# Altium schematic sheet Signal Connections.SchDoc("Signal Connections")
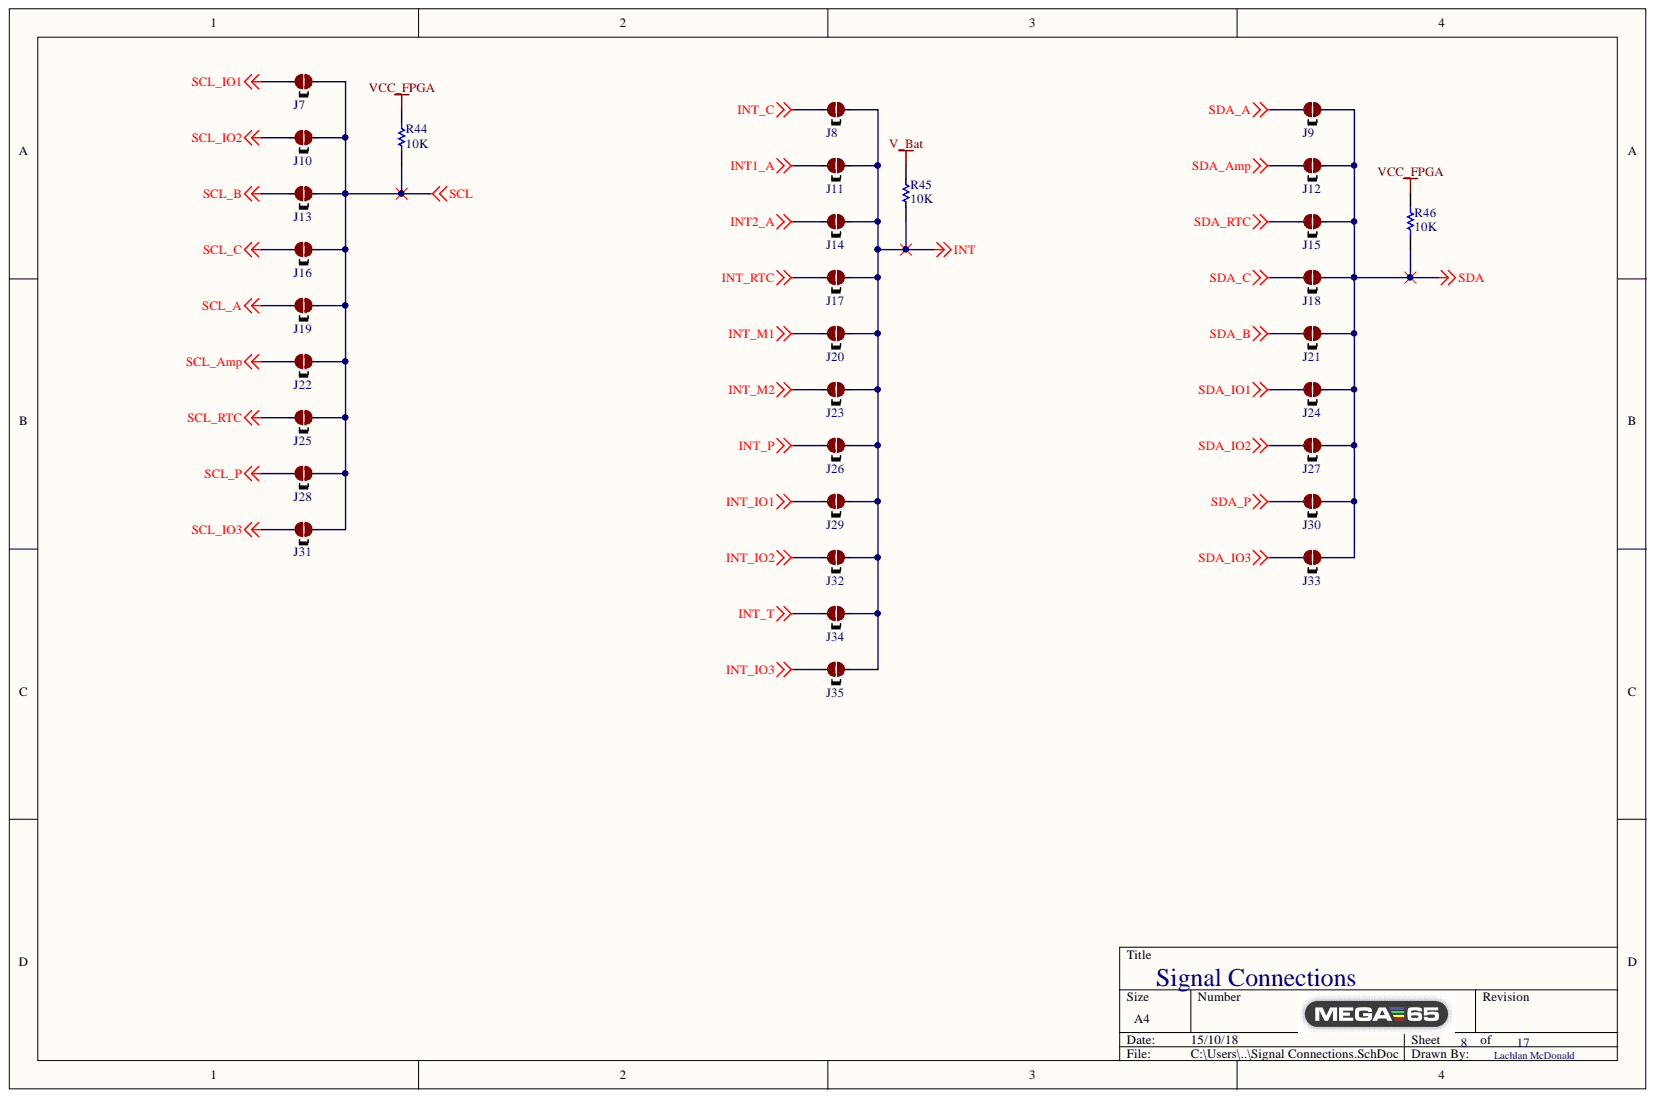
[diagram p0: Signal Connections.SchDoc]

## Parts
J7  2 way net solder junction - Normally Connected  [2waySolder_NC]  (value not drawn)  (no BOM)
J8  2 way net solder junction - Normally Connected  [2waySolder_NC]  (value not drawn)  (no BOM)
J9  2 way net solder junction - Normally Connected  [2waySolder_NC]  (value not drawn)  (no BOM)
J10  2 way net solder junction - Normally Connected  [2waySolder_NC]  (value not drawn)  (no BOM)
J11  2 way net solder junction - Normally Connected  [2waySolder_NC]  (value not drawn)  (no BOM)
J12  2 way net solder junction - Normally Connected  [2waySolder_NC]  (value not drawn)  (no BOM)
J13  2 way net solder junction - Normally Connected  [2waySolder_NC]  (value not drawn)
J14  2 way net solder junction - Normally Connected  [2waySolder_NC]  (value not drawn)  (no BOM)
J15  2 way net solder junction - Normally Connected  [2waySolder_NC]  (value not drawn)  (no BOM)
J16  2 way net solder junction - Normally Connected  [2waySolder_NC]  (value not drawn)
J17  2 way net solder junction - Normally Connected  [2waySolder_NC]  (value not drawn)  (no BOM)
J18  2 way net solder junction - Normally Connected  [2waySolder_NC]  (value not drawn)  (no BOM)
J19  2 way net solder junction - Normally Connected  [2waySolder_NC]  (value not drawn)
J20  2 way net solder junction - Normally Connected  [2waySolder_NC]  (value not drawn)  (no BOM)
J21  2 way net solder junction - Normally Connected  [2waySolder_NC]  (value not drawn)  (no BOM)
J22  2 way net solder junction - Normally Connected  [2waySolder_NC]  (value not drawn)
J23  2 way net solder junction - Normally Connected  [2waySolder_NC]  (value not drawn)  (no BOM)
J24  2 way net solder junction - Normally Connected  [2waySolder_NC]  (value not drawn)  (no BOM)
J25  2 way net solder junction - Normally Connected  [2waySolder_NC]  (value not drawn)
J26  2 way net solder junction - Normally Connected  [2waySolder_NC]  (value not drawn)  (no BOM)
J27  2 way net solder junction - Normally Connected  [2waySolder_NC]  (value not drawn)  (no BOM)
J28  2 way net solder junction - Normally Connected  [2waySolder_NC]  (value not drawn)
J29  2 way net solder junction - Normally Connected  [2waySolder_NC]  (value not drawn)  (no BOM)
J30  2 way net solder junction - Normally Connected  [2waySolder_NC]  (value not drawn)  (no BOM)
J31  2 way net solder junction - Normally Connected  [2waySolder_NC]  (value not drawn)
J32  2 way net solder junction - Normally Connected  [2waySolder_NC]  (value not drawn)  (no BOM)
J33  2 way net solder junction - Normally Connected  [2waySolder_NC]  (value not drawn)  (no BOM)
J34  2 way net solder junction - Normally Connected  [2waySolder_NC]  (value not drawn)  (no BOM)
J35  2 way net solder junction - Normally Connected  [2waySolder_NC]  (value not drawn)  (no BOM)
R44  10K  [RESC0805(2012)_M]
R45  10K  [RESC0805(2012)_M]
R46  10K  [RESC0805(2012)_M]

## Nets
INT: J8.2 J11.2 J14.2 J17.2 J20.2 J23.2 J26.2 J29.2 J32.2 J34.2 J35.2 R45.1
INT1_A: J11.1
INT2_A: J14.1
INT_C: J8.1
INT_IO1: J29.1
INT_IO2: J32.1
INT_IO3: J35.1
INT_M1: J20.1
INT_M2: J23.1
INT_P: J26.1
INT_RTC: J17.1
INT_T: J34.1
SCL: J7.2 J10.2 J13.2 J16.2 J19.2 J22.2 J25.2 J28.2 J31.2 R44.1
SCL_A: J19.1
SCL_Amp: J22.1
SCL_B: J13.1
SCL_C: J16.1
SCL_IO1: J7.1
SCL_IO2: J10.1
SCL_IO3: J31.1
SCL_P: J28.1
SCL_RTC: J25.1
SDA: J9.2 J12.2 J15.2 J18.2 J21.2 J24.2 J27.2 J30.2 J33.2 R46.1
SDA_A: J9.1
SDA_Amp: J12.1
SDA_B: J21.1
SDA_C: J18.1
SDA_IO1: J24.1
SDA_IO2: J27.1
SDA_IO3: J33.1
SDA_P: J30.1
SDA_RTC: J15.1
V_Bat: R45.2
VCC_FPGA: R44.2 R46.2

## Library data of the parts
J7-J35 = 2waySolderNC: Library Name: NetTieLib.IntLib; Description: 2 way net solder junction - Normally Connected; ComponentLink1URL: http://www.edatools.cz/addons; ComponentLink1Description: Source
  J13 J16 J19 J22 J25 J28 J31: Component Kind: Net Tie
R44-R46 = CMP-1013-00623-1: 10K 0.125W 1% 0805 (2012 Metric)  SMD; Value: 10000.00 Ohm; Case-Metric: 2012; Case-EIA: 0805; Tolerance: 1 %; Technology: SMT; Power: 0.125 W; Library Name: Altium Content Vault; Component Kind: Standard
